# Altium schematic sheet Auxiliary.SchDoc
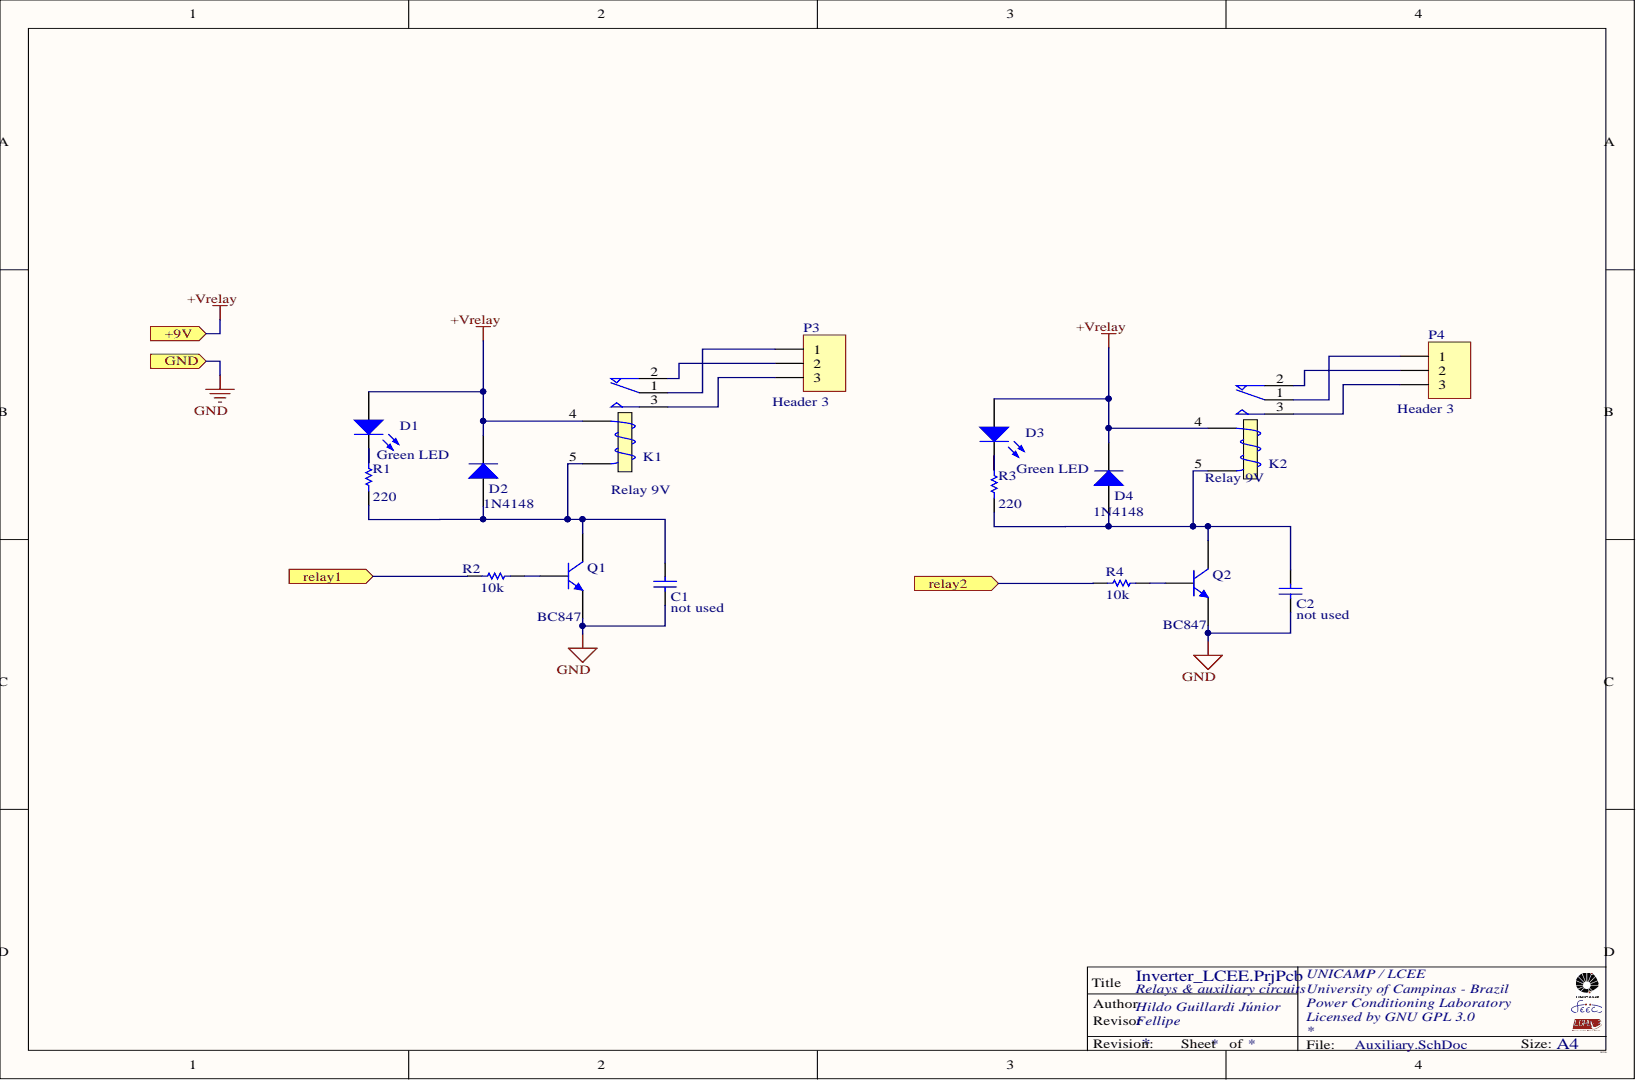
[diagram p0: Auxiliary.SchDoc]

## Parts
C1  value not used  [0805_W]
C2  value not used  [0805_W]
D1  value Green LED  [LED_0805]
D2  value 1N4148  [SOD-123]
D3  value Green LED  [LED_0805]
D4  value 1N4148  [SOD-123]
K1  value Relay 9V  [Relay - SPDT]
K2  value Relay 9V  [Relay - SPDT]
P3  value Header 3  [KK-3_G]
P4  value Header 3  [KK-3_G]
Q1  value BC847  [SOT-23-TT3_L]
Q2  value BC847  [SOT-23-TT3_L]
R1  value 220  [0805_W]
R2  value 10k  [0805_W]
R3  value 220  [0805_W]
R4  value 10k  [0805_W]

## Ports
+9V, GND, RELAY1, RELAY2

## Nets
+VRELAY: D1.1 D2.2 D3.1 D4.2 K1.4 K2.4 ; port +9V
GND: C1.1 C2.1 Q1.2 Q2.2 ; port GND
NetC1_2: C1.2 D2.1 K1.5 Q1.3 R1.2
NetC2_2: C2.2 D4.1 K2.5 Q2.3 R3.2
NetD1_2: D1.2 R1.1
NetD3_2: D3.2 R3.1
NetK1_1: K1.1 P3.1
NetK1_2: K1.2 P3.2
NetK1_3: K1.3 P3.3
NetK2_1: K2.1 P4.1
NetK2_2: K2.2 P4.2
NetK2_3: K2.3 P4.3
NetQ1_1: Q1.1 R2.2
NetQ2_1: Q2.1 R4.2
NetR2_1: R2.1 ; port RELAY1
NetR4_1: R4.1 ; port RELAY2

## Library data of the parts
C1 C2 = Cap: Capacitor; Component Kind: Standard; LatestRevisionDate: 17-Jul-2002; LatestRevisionNote: Re-released for DXP Platform.; Library Name: Miscellaneous Devices.IntLib; PackageReference: RAD-0.3; Published: 8-Jun-2000; Signal Integrity: Cap; Simulation: CAP; Value: Value; Publisher: Altium Limited
D1 = LED0: Typical INFRARED GaAs LED; Component Kind: Standard; LatestRevisionDate: 08-Jul-2005; LatestRevisionNote: Mech Layer 1 removed.; Library Name: Miscellaneous Devices.IntLib; PackageDescription: LED; 2 Leads; PackageReference: LED-0; Published: 8-Jun-2000; Publisher: Altium Limited
D2 D4 = Diode 1N4148: High Conductance Fast Diode; Code_JEDEC: DO-204-AH; Component Kind: Standard; ComponentLink1Description: Manufacturer Link; ComponentLink1URL: http://www.fairchildsemi.com/; ComponentLink2Description: Datasheet; ComponentLink2URL: http://www.fairchildsemi.com/ds/1N%2F1N4148.pdf; DatasheetVersion: 1997; LatestRevisionDate: 17-Jul-2002; LatestRevisionNote: Re-released for DXP Platform.; Library Name: Miscellaneous Devices.IntLib; PackageReference: DO-35; Published: 8-Jun-2000; Signal Integrity: 1N4148; Simulation: 1N4148; Publisher: Altium Limited
D3 = LED0: Typical INFRARED GaAs LED; Value: Green LED; Component Kind: Standard; LatestRevisionDate: 08-Jul-2005; LatestRevisionNote: Mech Layer 1 removed.; Library Name: Miscellaneous Devices.IntLib; PackageDescription: LED; 2 Leads; PackageReference: LED-0; Published: 8-Jun-2000; Publisher: Altium Limited
K1 K2 = Relay: SPDT Relay; Component Kind: Standard; LatestRevisionDate: 17-Jul-2002; LatestRevisionNote: Re-released for DXP Platform.; Library Name: Miscellaneous Devices.IntLib; PackageReference: MODULE5B; Published: 8-Jun-2000; Publisher: Altium Limited
  K1: Simulation: SPDTRELAY
P3 P4 = Header 3: Header, 3-Pin; Component Kind: Standard; LatestRevisionDate: 17-Jul-2002; LatestRevisionNote: Re-released for DXP Platform.; Signal Integrity: Connector; Library Name: AQAC.SCHLIB; Publisher: Altium Limited
Q1 Q2 = BC847: NPN General-purpose Transistor; Component Kind: Standard; ComponentLink1Description: Manufacturer Link; ComponentLink1URL: http://www.semiconductors.philips.com/; ComponentLink2Description: Datasheet; ComponentLink2URL: http://www.semiconductors.philips.com/acrobat/datasheets/BC846_BC847_BC848_5.pdf; DatasheetVersion: 4-Feb-2002; LatestRevisionDate: 12-Dec-2006; LatestRevisionNote: IPC-7351 Footprint Added.; Library Name: Philips Discrete BJT - Low Power.IntLib; PackageDescription: 3-Pin SOT-23 Package 0.95 mm Pitch, 2.3 mm Lead Span; PackageReference: SOT23; PackageVersion: May-1999; Published: 17-Sep-2003; Signal Integrity: BC847; Simulation: QBC847; Publisher: Altium Limited
R1 R3 = Res_0805: Resistor; Manufacturer: Generic Components; Class: Passive; Component Kind: Standard; Published: 08/06/2000; Signal Integrity: Res1; Simulation: RESISTOR; SubClass: Resistor; Value: Value; Library Name: Inversor_LCEE.SchLib; Publisher: Altium Hobart Technology Centre
R2 R4 = Res1: Resistor; Component Kind: Standard; LatestRevisionDate: 17-Jul-2002; LatestRevisionNote: Re-released for DXP Platform.; Library Name: Miscellaneous Devices.IntLib; PackageReference: AXIAL-0.3; Published: 8-Jun-2000; Signal Integrity: Res; Simulation: RESISTOR; Value: Value; Publisher: Altium Limited
